# Altium schematic sheet UART_LOGIC.SchDoc
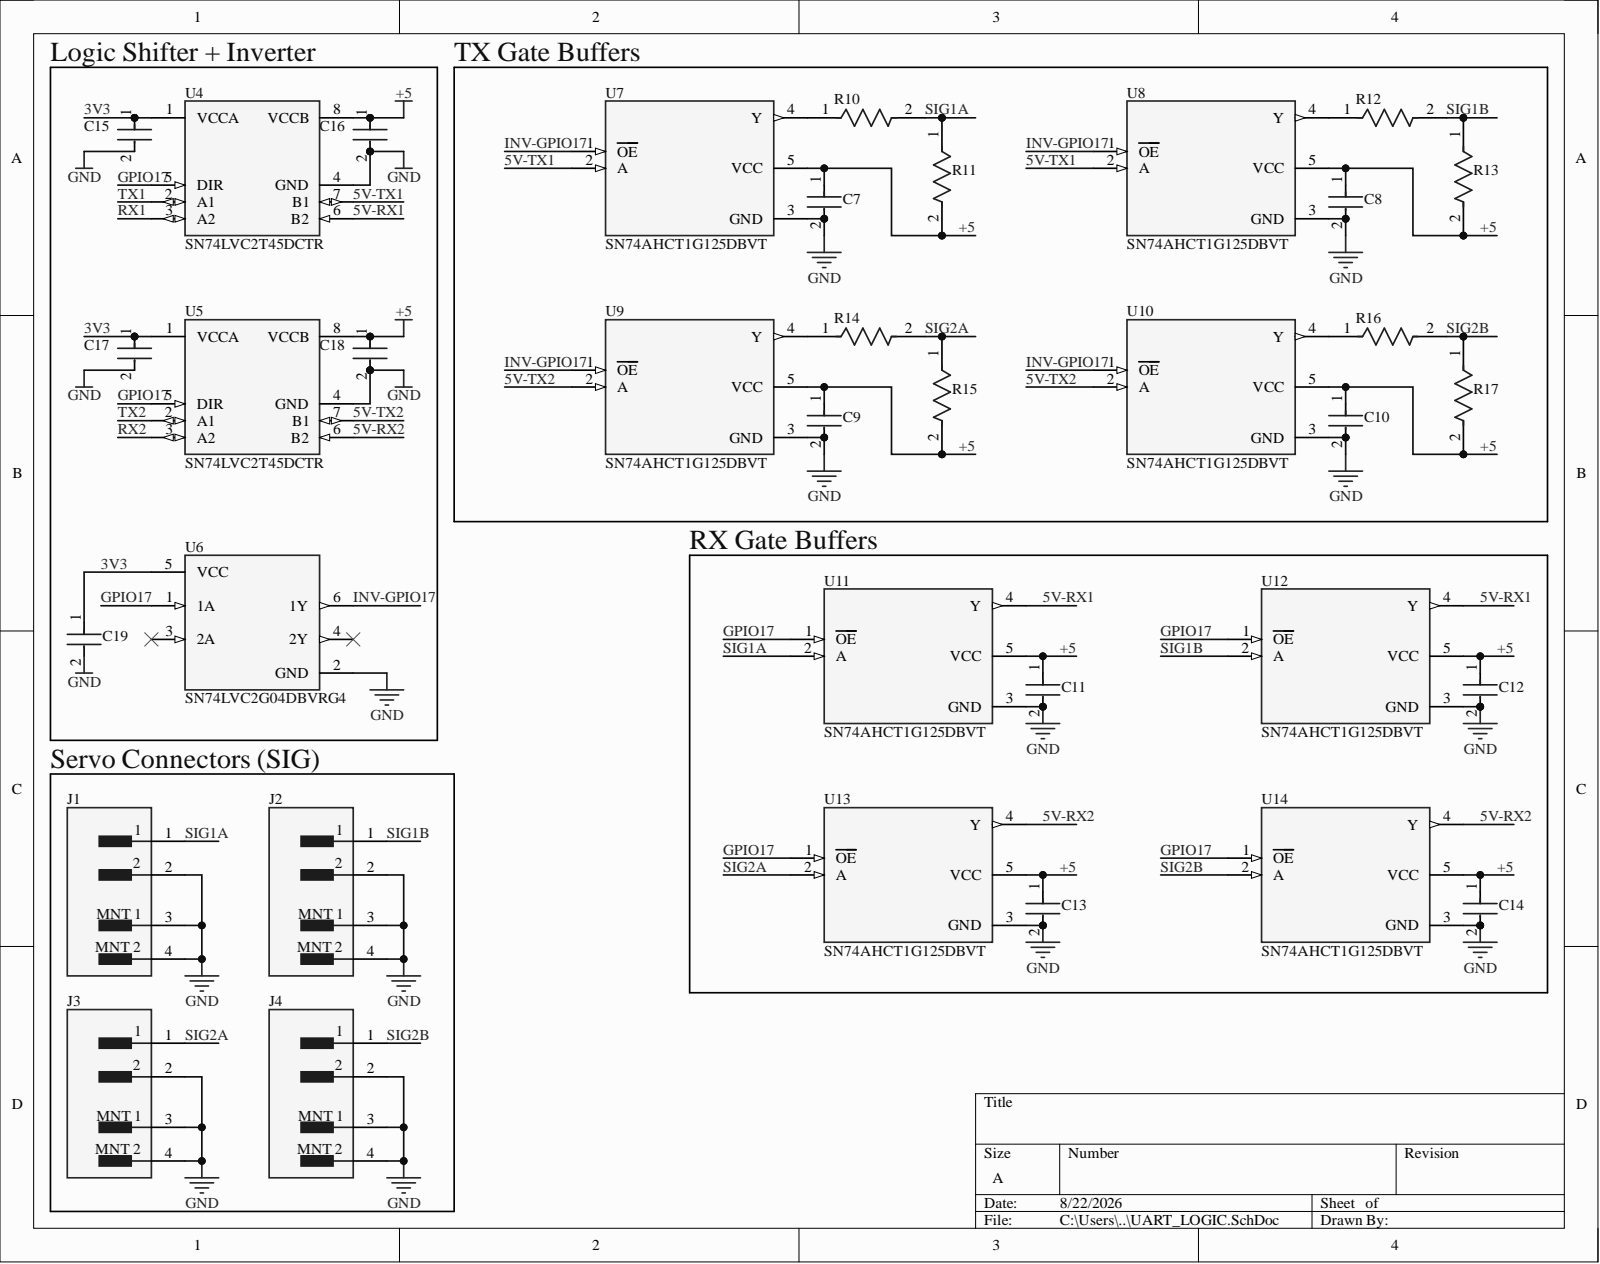
[diagram p0: UART_LOGIC.SchDoc]

## Parts
C7  CC0805KRX7R9BB104  [FP-CC0805-0_85-MFG]  (value not drawn)
C8  CC0805KRX7R9BB104  [FP-CC0805-0_85-MFG]  (value not drawn)
C9  CC0805KRX7R9BB104  [FP-CC0805-0_85-MFG]  (value not drawn)
C10  CC0805KRX7R9BB104  [FP-CC0805-0_85-MFG]  (value not drawn)
C11  CC0805KRX7R9BB104  [FP-CC0805-0_85-MFG]  (value not drawn)
C12  CC0805KRX7R9BB104  [FP-CC0805-0_85-MFG]  (value not drawn)
C13  CC0805KRX7R9BB104  [FP-CC0805-0_85-MFG]  (value not drawn)
C14  CC0805KRX7R9BB104  [FP-CC0805-0_85-MFG]  (value not drawn)
C15  CC0805KRX7R9BB104  [FP-CC0805-0_85-MFG]  (value not drawn)
C16  CC0805KRX7R9BB104  [FP-CC0805-0_85-MFG]  (value not drawn)
C17  CC0805KRX7R9BB104  [FP-CC0805-0_85-MFG]  (value not drawn)
C18  CC0805KRX7R9BB104  [FP-CC0805-0_85-MFG]  (value not drawn)
C19  CC0805KRX7R9BB104  [FP-CC0805-0_85-MFG]  (value not drawn)
J1  BM02B-SRSS-TBT(LF)(SN)  [FP-BM02B-SRSS-TBT_LF_SN-MFG]  (value not drawn)
J2  BM02B-SRSS-TBT(LF)(SN)  [FP-BM02B-SRSS-TBT_LF_SN-MFG]  (value not drawn)
J3  BM02B-SRSS-TBT(LF)(SN)  [FP-BM02B-SRSS-TBT_LF_SN-MFG]  (value not drawn)
J4  BM02B-SRSS-TBT(LF)(SN)  [FP-BM02B-SRSS-TBT_LF_SN-MFG]  (value not drawn)
R10  RMCF0603FG47R0  [FP-RMCF0603-IPC_A]  (value not drawn)
R11  RC0603FR-0710KL  [FP-RC0603-0_55-IPC_C]  (value not drawn)
R12  RMCF0603FG47R0  [FP-RMCF0603-IPC_A]  (value not drawn)
R13  RC0603FR-0710KL  [FP-RC0603-0_55-IPC_C]  (value not drawn)
R14  RMCF0603FG47R0  [FP-RMCF0603-IPC_A]  (value not drawn)
R15  RC0603FR-0710KL  [FP-RC0603-0_55-IPC_C]  (value not drawn)
R16  RMCF0603FG47R0  [FP-RMCF0603-IPC_A]  (value not drawn)
R17  RC0603FR-0710KL  [FP-RC0603-0_55-IPC_C]  (value not drawn)
U4  SN74LVC2T45DCTR  [FP-DCT0008A-IPC_C]
U5  SN74LVC2T45DCTR  [FP-DCT0008A-IPC_C]
U6  SN74LVC2G04DBVRG4  [FP-DBV0006A-MFG]
U7  SN74AHCT1G125DBVT  [SOT95P280X145-5N]
U8  SN74AHCT1G125DBVT  [SOT95P280X145-5N]
U9  SN74AHCT1G125DBVT  [SOT95P280X145-5N]
U10  SN74AHCT1G125DBVT  [SOT95P280X145-5N]
U11  SN74AHCT1G125DBVT  [SOT95P280X145-5N]
U12  SN74AHCT1G125DBVT  [SOT95P280X145-5N]
U13  SN74AHCT1G125DBVT  [SOT95P280X145-5N]
U14  SN74AHCT1G125DBVT  [SOT95P280X145-5N]

## Nets
GND: C7.2 C8.2 C9.2 C10.2 C11.2 C12.2 C13.2 C14.2 C15.2 C16.2 C17.2 C18.2 C19.2 J1.2 J1.3 J1.4 J2.2 J2.3 J2.4 J3.2 J3.3 J3.4 J4.2 J4.3 J4.4 U4.4(GND) U5.4(GND) U6.2(GND) U7.3(GND) U8.3(GND) U9.3(GND) U10.3(GND) U11.3(GND) U12.3(GND) U13.3(GND) U14.3(GND)
3V3: C15.1 C17.1 C19.1 U4.1(VCCA) U5.1(VCCA) U6.5(VCC)
5V-RX1: C7.1 C8.1 C9.1 C10.1 C11.1 C12.1 C13.1 C14.1 C16.1 C18.1 R11.2 R13.2 R15.2 R17.2 U4.6(B2) U4.8(VCCB) U5.8(VCCB) U7.5(VCC) U8.5(VCC) U9.5(VCC) U10.5(VCC) U11.4(Y) U11.5(VCC) U12.4(Y) U12.5(VCC) U13.5(VCC) U14.5(VCC)  [also labelled +5]
5V-RX2: U5.6(B2) U13.4(Y) U14.4(Y)
5V-TX1: U4.7(B1) U7.2(A) U8.2(A)
5V-TX2: U5.7(B1) U9.2(A) U10.2(A)
GPIO17: U4.5(DIR) U5.5(DIR) U6.1(1A) U11.1(OE) U12.1(OE) U13.1(OE) U14.1(OE)
INV-GPIO17: U6.6(1Y) U7.1(OE) U8.1(OE) U9.1(OE) U10.1(OE)
RX1: U4.3(A2)
RX2: U5.3(A2)
SIG1A: J1.1 R10.2 R11.1 U11.2(A)
SIG1B: J2.1 R12.2 R13.1 U12.2(A)
SIG2A: J3.1 R14.2 R15.1 U13.2(A)
SIG2B: J4.1 R16.2 R17.1 U14.2(A)
TX1: U4.2(A1)
TX2: U5.2(A1)
NetR10_1: R10.1 U7.4(Y)
NetR12_1: R12.1 U8.4(Y)
NetR14_1: R14.1 U9.4(Y)
NetR16_1: R16.1 U10.4(Y)

Not connected: U6 3(2A) 4(2Y)

## Library data of the parts
C7-C19 = CMP-2100-03606-3: CAP CER 0.1UF 50V X7R 0805; Manufacturer: Yageo; Capacitance: 100 nF; Material: Ceramic; Tolerance: 0.1; Value: 100 nF; Automotive: No; Category: Cap; Component Kind: Standard; ComponentLink2Description: Datasheet; ComponentLink2URL: http://www.yageo.com/documents/recent/UPY-GPHC_Y5V_6.3V-to-50V_8.pdf; Device Class L1: Passive Components; Device Class L2: Capacitors; Device Class L3: Ceramic Capacitors; Digikey Description: CAP CER 0.1UF 50V X7R 0805; Footprint Url: http://www.yageo.com/exep/pages/download/literatures/UPY-C_GEN_24.pdf; Height: 0.95mm; Ipc Land Pattern Name: CAPC200125X85; Lead Free: Yes; Library Name: Altium Content Vault; Manufacturer Part Number: CC0805KRX7R9BB104; Mouser Description: Multilayer Ceramic Capacitors MLCC - SMD/SMT 100nF 50V X7R 10%; Octopart: https://octopart.com/cc0805krx7r9bb104-yageo-192993; Package: CC0805-0.85; RoHS: TRUE; Temperature: -30°C to +85°C; Temperature Characteristic: X7R; Temperature Range High: +85°C; Temperature Range Low: -30°C; Voltage Rating: 50 V
J1-J4 = CMP-17439-000179-1: CONN WIRE HDR 2POS 1MM STSMD; Connector Type: Header; Contact Resistance: 20mR; Mated Stacking Heights: 4.9mm; Max Current Rating: 1A; Max Voltage Rating (AC): 50V; Number of Positions: 2; Pitch: 0.039inch; Color: Natural; Component Kind: Standard; Contact Finish - Mating: TIN; Contact Material: Copper Alloy; Contact Plating: Tin; Contact Shape: Square; Contact Type: Male Pin; Depth: 3.6mm; Fastening Type: Pull; Flammability Rating: UL94 V-0; Housing Color: Natural; Housing Material: Polyamide; Insulation Color: Natural; Insulation Material: Nylon; Lead Free: Lead Free; Length: 4mm; Library Name: Altium Content Vault; Max Operating Temperature: 85°C; Max Voltage Rating (DC): 50V; Min Operating Temperature: -25°C; Mount: Surface Mount; Number of Rows: 1; Orientation: Straight; Package Quantity: 1500; Packaging: Tape and Reel; Series: SR; Termination: Solder; Voltage Rating: 50V
R10 R12 R14 R16 = CMP-26527-000678-1: 47Ω ±1% 0.1W 0603 Thick Film Chip Resistor AEC-Q200 compliant; Power Rating: 100mW; Resistance: 47R; Case/Package: 0603; Component Kind: Standard; Library Name: Altium Content Vault; RoHS Compliant: Yes
R11 R13 R15 R17 = CMP-2100-03664-2: RES 10K OHM 1% 1/10W 0603; Max Power Dissipation: 100mW; Resistance: 10kR; Temperature Coefficient: 100ppm/°C; Voltage Rating: 75V; Voltage Rating (DC): 50V; Case Code (Imperial): 0603; Case Code (Metric): 1608; Case/Package: 0603; Component Kind: Standard; Construction: Rectangular; Depth: 0.8mm; Features: Moisture Resistant; Height: 0.45mm; Lead Free: Lead Free; Lead Pitch: 0.3mm; Length: 1.6mm; Library Name: Altium Content Vault; Max Operating Temperature: 155°C; Military Standard: Not; Min Operating Temperature: -55°C; Number of Pins: 2; Number of Terminations: 2; Packaging: Tape and Reel; Power Rating: 100mW; Resistance Tolerance: 1%; Resistor Type: Thick Film; RoHS Compliant: Yes; Series: RC; Size Code: 0603; Termination: SMD/SMT; Tolerance: 1%; Weight: 7.1E-05oz; Width: 0.8mm
U4 U5 = CMP-0000-1: 2-Bit Dual Supply Transceiver with Configurable Voltage-Level Shifting and 3-State Outputs 8-SSOP -40 to 85; Manufacturer Lifecycle Status: ACTIVE; Data Rate: 420Mbps; Direction: Bidirectional; High Level Output Current: -32mA; Logic Function: Transceiver, Translator; Low Level Output Current: 32mA; Max Junction Temperature (Tj): 150°C; Max Supply Voltage: 5.5V; Min Supply Voltage: 1.65V; Number of Bits: 2; Number of Circuits: 1; Output Current: 50mA; Polarity: Non-Inverting; Propagation Delay: 45.2ns; Quiescent Current: 3µA; Turn-On Delay Time: 17.7ns; Ambient Temperature Range High: 85°C; Case/Package: SSOP; Component Kind: Standard; Contact Plating: Gold; Height: 1.3mm; Lead Free: Lead Free; Length: 2.95mm; Lifecycle Status: Production; Max Operating Temperature: 85°C; Min Operating Temperature: -40°C; Number of Channels: 2; Number of Elements: 1; Number of Inputs: 1; Number of Outputs: 1; Packaging: Cut Tape; Pins: 8; Radiation Hardening: No; REACH SVHC: No; RoHS: Compliant; Schedule B: 8542390000, 8542390000; Thickness: 1.29mm; Weight: 23.388357mg; Width: 2.8mm; Library Name: Bryan Heddle
U6 = CMP-04903-000086-1: IC INVERTER 2CH 2-INP SOT23-6; High Level Output Current: -32mA; Low Level Output Current: 32mA; Max Supply Voltage: 5.5V; Min Supply Voltage: 1.65V; Number of Bits: 2; Operating Supply Voltage: 5V; Propagation Delay: 3.7ns; Quiescent Current: 10uA; Turn-On Delay Time: 8ns; Case/Package: SOT-23-6; Component Kind: Standard; Lead Free: Lead Free; Library Name: Altium Content Vault; Logic Function: Inverter; Max Operating Temperature: 125°C; Min Operating Temperature: -40°C; Mount: Surface Mount; Number of Circuits: 2; Number of Elements: 2; Number of Gates: 2; Number of Inputs: 2; Number of Pins: 6; Package Quantity: 3000; Packaging: Tape and Reel; Radiation Hardening: No; RoHS Compliant: Yes; Series: 74LVC; Technology: CMOS; Weight: 0.000557oz
U7-U14 = CMP-009-000000-3: Single 4.5-V to 5.5-V buffer with TTL-compatible CMOS inputs and 3-state outputs; MF: Texas Instruments; Check_prices: https://www.snapeda.com/parts/SN74AHCT1G125DBVT/Texas+Instruments/view-part/?ref=eda; Component Kind: Standard; Component Type: Standard; Design Item ID: SN74AHCT1G125DBVT; MP: SN74AHCT1G125DBVT; Package: SOT-23-5 Texas Instruments; Pin Count: 5; SnapEDA_Link: https://www.snapeda.com/parts/SN74AHCT1G125DBVT/Texas+Instruments/view-part/?ref=snap; Source: SN74AHCT1G125DBVT.SchLib; Library Name: Bryan Heddle
